# Altium schematic sheet ESP32 Relay_PWR.SchDoc("ESP32 Relay_PWR")
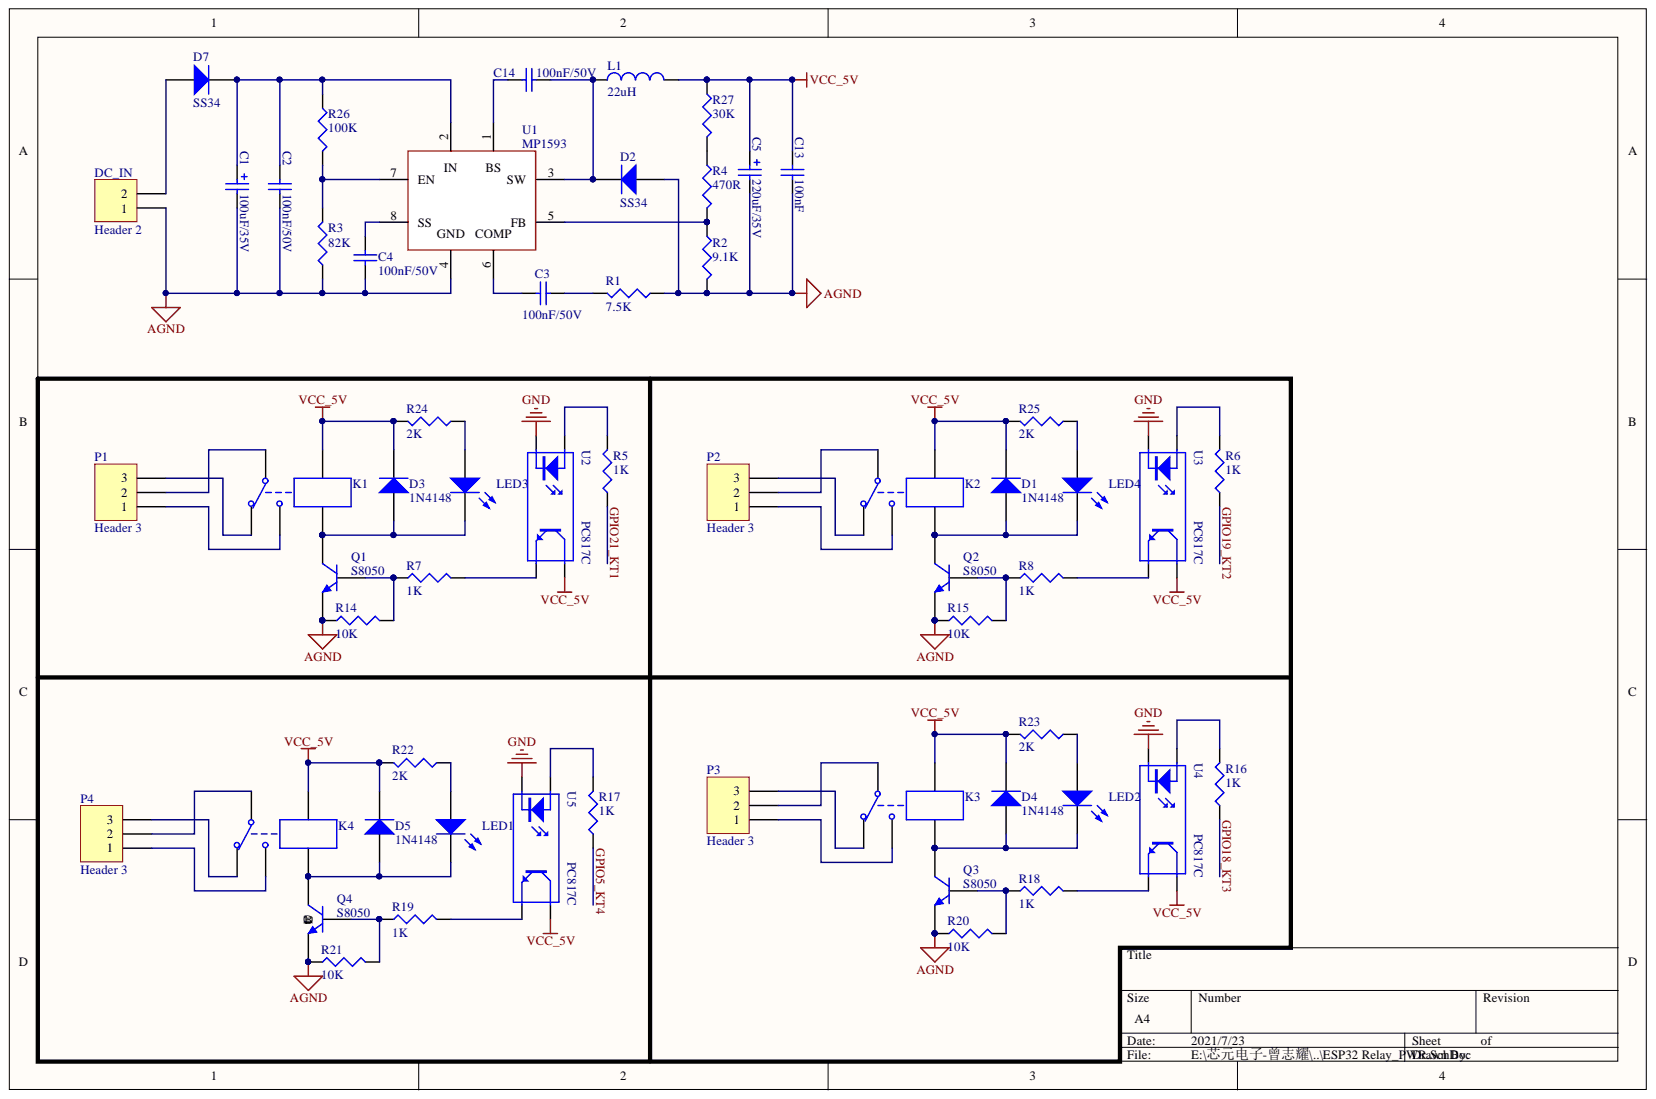
[diagram p0: ESP32 Relay_PWR.SchDoc]

## Parts
C1  220uF/35V  value 100uF/35V  [CM D(6.3*5.4)]
C2  100nF/50V  [C0603]
C3  100nF/50V  [C0402]
C4  100nF/50V  [C0402]
C5  value 220uF/35V  [CM D(6.3*7.7)]  (hidden comment: 220uF/16V)
C13  100nF  [C0402]
C14  100nF/50V  [C0603]
D1  1N4148  [SOD323]
D2  SS34  [SMA]
D3  1N4148  [SOD323]
D4  1N4148  [SOD323]
D5  1N4148  [SOD323]
D7  SS34  [SMA]
DC_IN  Header 2  [KF128-5.08-2P]
K1  G5LE-14- 5VDC  [RELAY-TH_G5LE-14-XX]  (value not drawn)
K2  G5LE-14- 5VDC  [RELAY-TH_G5LE-14-XX]  (value not drawn)
K3  G5LE-14- 5VDC  [RELAY-TH_G5LE-14-XX]  (value not drawn)
K4  G5LE-14- 5VDC  [RELAY-TH_G5LE-14-XX]  (value not drawn)
L1  value 22uH  [IND-SMD_L6.7-W6.7]  (hidden comment: Inductor)
LED1  RED  [LED0603]  (value not drawn)
LED2  RED  [LED0603]  (value not drawn)
LED3  RED  [LED0603]  (value not drawn)
LED4  RED  [LED0603]  (value not drawn)
P1  Header 3  [KF128-5.08-3P]
P2  Header 3  [KF128-5.08-3P]
P3  Header 3  [KF128-5.08-3P]
P4  Header 3  [KF128-5.08-3P]
Q1  S8050  [sot-23]
Q2  S8050  [sot-23]
Q3  S8050  [sot-23]
Q4  S8050  [sot-23]
R1  7.5K  [0402A]
R2  9.1K  [0402A]
R3  82K  [R0603]
R4  470R  [0402A]
R5  1K  [0402A]
R6  1K  [0402A]
R7  1K  [R0603]
R8  1K  [R0603]
R14  10K  [R0603]
R15  10K  [R0603]
R16  1K  [0402A]
R17  1K  [0402A]
R18  1K  [R0603]
R19  1K  [R0603]
R20  10K  [R0603]
R21  10K  [R0603]
R22  2K  [R0603]
R23  2K  [R0603]
R24  2K  [R0603]
R25  2K  [R0603]
R26  100K  [R0603]
R27  30K  [0402A]
U1  MP1593  [SOP8-散热焊盘]
U2  PC817C  [SOP-4_L6.5-W4.6-P2.54-LS10.2-BL]
U3  PC817C  [SOP-4_L6.5-W4.6-P2.54-LS10.2-BL]
U4  PC817C  [SOP-4_L6.5-W4.6-P2.54-LS10.2-BL]
U5  PC817C  [SOP-4_L6.5-W4.6-P2.54-LS10.2-BL]

## Nets
AGND: C1.2 C2.1 C4.2 C5.2 C13.2 D2.1 DC_IN.1 Q1.3 Q2.3 Q3.3 Q4.3 R1.1 R2.2 R3.1 R14.1 R15.1 R20.1 R21.1 U1.4
GND: U2.2 U3.2 U4.2 U5.2
VCC_5V: C5.1 C13.1 D1.2 D3.2 D4.2 D5.2 K1.4 K2.4 K3.4 K4.4 L1.2 R22.2 R23.2 R24.2 R25.2 R27.1 U2.4 U3.4 U4.4 U5.4
GPIO5_KT4: R17.1
GPIO18_KT3: R16.1
GPIO19_KT2: R6.1
GPIO21_KT1: R5.1
NetC1_1: C1.1 C2.2 D7.2 R26.1 U1.2(IN)
NetC3_1: C3.1 U1.6
NetC3_2: C3.2 R1.2
NetC4_1: C4.1 U1.8(SS)
NetC14_1: C14.1 U1.1(BS)
NetC14_2: C14.2 D2.2 L1.1 U1.3(SW)
NetD1_1: D1.1 K2.5 LED4.2 Q2.1
NetD3_1: D3.1 K1.5 LED3.2 Q1.1
NetD4_1: D4.1 K3.5 LED2.2 Q3.1
NetD5_1: D5.1 K4.5 LED1.2 Q4.1
NetD7_1: D7.1 DC_IN.2
NetK1_1: K1.1 P1.2
NetK1_2: K1.2 P1.3
NetK1_3: K1.3 P1.1
NetK2_1: K2.1 P2.2
NetK2_2: K2.2 P2.3
NetK2_3: K2.3 P2.1
NetK3_1: K3.1 P3.2
NetK3_2: K3.2 P3.3
NetK3_3: K3.3 P3.1
NetK4_1: K4.1 P4.2
NetK4_2: K4.2 P4.3
NetK4_3: K4.3 P4.1
NetLED1_1: LED1.1 R22.1
NetLED2_1: LED2.1 R23.1
NetLED3_1: LED3.1 R24.1
NetLED4_1: LED4.1 R25.1
NetQ1_2: Q1.2 R7.1 R14.2
NetQ2_2: Q2.2 R8.1 R15.2
NetQ3_2: Q3.2 R18.1 R20.2
NetQ4_2: Q4.2 R19.1 R21.2
NetR2_1: R2.1 R4.2 U1.5(FB)
NetR3_2: R3.2 R26.2 U1.7(EN)
NetR4_1: R4.1 R27.2
NetR5_2: R5.2 U2.1
NetR6_2: R6.2 U3.1
NetR7_2: R7.2 U2.3
NetR8_2: R8.2 U3.3
NetR16_2: R16.2 U4.1
NetR17_2: R17.2 U5.1
NetR18_2: R18.2 U4.3
NetR19_2: R19.2 U5.3

## Library data of the parts
C1 C5 = Cap Pol2: Polarized Capacitor (Axial); Simulation: CAP; Signal Integrity: Cap Pol; Published: 8-Jun-2000; PackageReference: POLAR0.8; PackageDescription: Polarized Capacitor; 2 Leads; Library Name: Miscellaneous Devices.IntLib; LatestRevisionNote: Re-released for DXP Platform.; LatestRevisionDate: 17-Jul-2002; Component Kind: Standard; Publisher: Altium Limited
C2-C4 C13 C14 = Cap: Capacitor; Value: 100pF; Simulation: CAP; Signal Integrity: Cap; Published: 8-Jun-2000; PackageReference: RAD-0.3; PackageDescription: Radial Cap, Thru-Hole; 2 Leads; 0.3 in Pin Spacing; Library Name: Miscellaneous Devices.IntLib; LatestRevisionNote: Re-released for DXP Platform.; LatestRevisionDate: 17-Jul-2002; Component Kind: Standard; Publisher: Altium Limited
D1-D5 D7 = Diode: Default Diode; Simulation: DIODE; Signal Integrity: Diode; Published: 8-Jun-2000; PackageVersion: Sep-1996; PackageReference: SMC; PackageDescription: DO-214-AB/SMC; 2 C-Bend Leads; Body 7.9 x 5.9 mm, inc. leads (LxW); Library Name: Miscellaneous Devices.IntLib; LatestRevisionNote: Re-released for DXP Platform.; LatestRevisionDate: 17-Jul-2002; Component Kind: Standard; Code_JEDEC: DO-214-AB; Publisher: Altium Limited
DC_IN = Header 2: Header, 2-Pin; Signal Integrity: Connector; Library Name: Miscellaneous Connectors.IntLib; LatestRevisionNote: Re-released for DXP Platform.; LatestRevisionDate: 17-Jul-2002; Component Kind: Standard; Publisher: Altium Limited
K1-K4 = Relay-SPDT: Single-Pole Dual-Throw Relay; Simulation: SPDTRELAY; Published: 24-Mar-1999; PackageReference: MODULE5B; Library Name: Miscellaneous Devices.IntLib; LatestRevisionNote: Re-released for DXP Platform.; LatestRevisionDate: 17-Jul-2002; Component Kind: Standard; Publisher: Altium Limited
L1 = Inductor: Code_IEC: 1005; Simulation: INDUCTOR; Signal Integrity: Inductor; Published: 8-Jun-2000; PackageReference: 0402-A; PackageDescription: Chip Inductor; Library Name: Miscellaneous Devices.IntLib; LatestRevisionNote: IPC-7351 Footprint Added.; LatestRevisionDate: 29-May-2009; Description: Inductor; Component Kind: Standard; Code_JEITA: 0402; Publisher: Altium Limited
LED1-LED4 = LED2: Typical RED, GREEN, YELLOW, AMBER GaAs LED; Simulation: LED2; Published: 8-Jun-2000; PackageVersion: Feb-2002; PackageReference: 3.2X1.6X1.1; PackageDescription: SM LED; 2 Flat Leads; Library Name: Miscellaneous Devices.IntLib; LatestRevisionNote: Re-released for DXP Platform.; LatestRevisionDate: 17-Jul-2002; Component Kind: Standard; Publisher: Altium Limited
P1-P4 = Header 3: Header, 3-Pin; Signal Integrity: Connector; Library Name: Miscellaneous Connectors.IntLib; LatestRevisionNote: Re-released for DXP Platform.; LatestRevisionDate: 17-Jul-2002; Component Kind: Standard; Publisher: Altium Limited
Q1-Q4 = NPN: NPN Bipolar Transistor; Simulation: NPN; Signal Integrity: NPN; Published: 8-Jun-2000; PackageReference: TO-226-AA; PackageDescription: TO, Flat Index; 3 In-Line, Axial Leads; Body Dia. 4.8mm; Leads 0.55 x 0.51 mm (max); Library Name: Miscellaneous Devices.IntLib; LatestRevisionNote: Re-released for DXP Platform.; LatestRevisionDate: 17-Jul-2002; Component Kind: Standard; Code_JEDEC: TO-226-AA; Publisher: Altium Limited
R1-R8 R14-R27 = Res3: Resistor; Simulation: RESISTOR; Signal Integrity: Res; Published: 8-Jun-2000; PackageReference: J1-0603; PackageDescription: Chip Resistor; Library Name: Miscellaneous Devices.IntLib; LatestRevisionNote: IPC-7351 Footprint Added.; LatestRevisionDate: 29-May-2009; Component Kind: Standard; Publisher: Altium Limited
  R1: Value: 7.5K
  R2: Value: 9.1K
  R3: Value: 82K
  R4: Value: 470R
  R5-R8 R16-R19: Value: 1K
  R14 R15 R20 R21: Value: 10K
  R22-R25: Value: 2K
  R26: Value: 100K
  R27: Value: 30K
U2-U5 = Optoisolator1: 4-Pin Phototransistor Optocoupler; Published: 24-Mar-1999; PackageReference: DIP-4; Library Name: Miscellaneous Devices.IntLib; LatestRevisionNote: Sch pins 3 & 4 Swapped.; LatestRevisionDate: 04-Mar-2005; DatasheetVersion: Nov-2003; Component Kind: Standard; Publisher: Altium Limited
U1 = MP1593: Library Name: 原理图库.SchLib; Component Kind: Standard
